# Altium schematic sheet PSDT ADC v1.0.0.SchDoc("PSDT ADC v1.0.0")
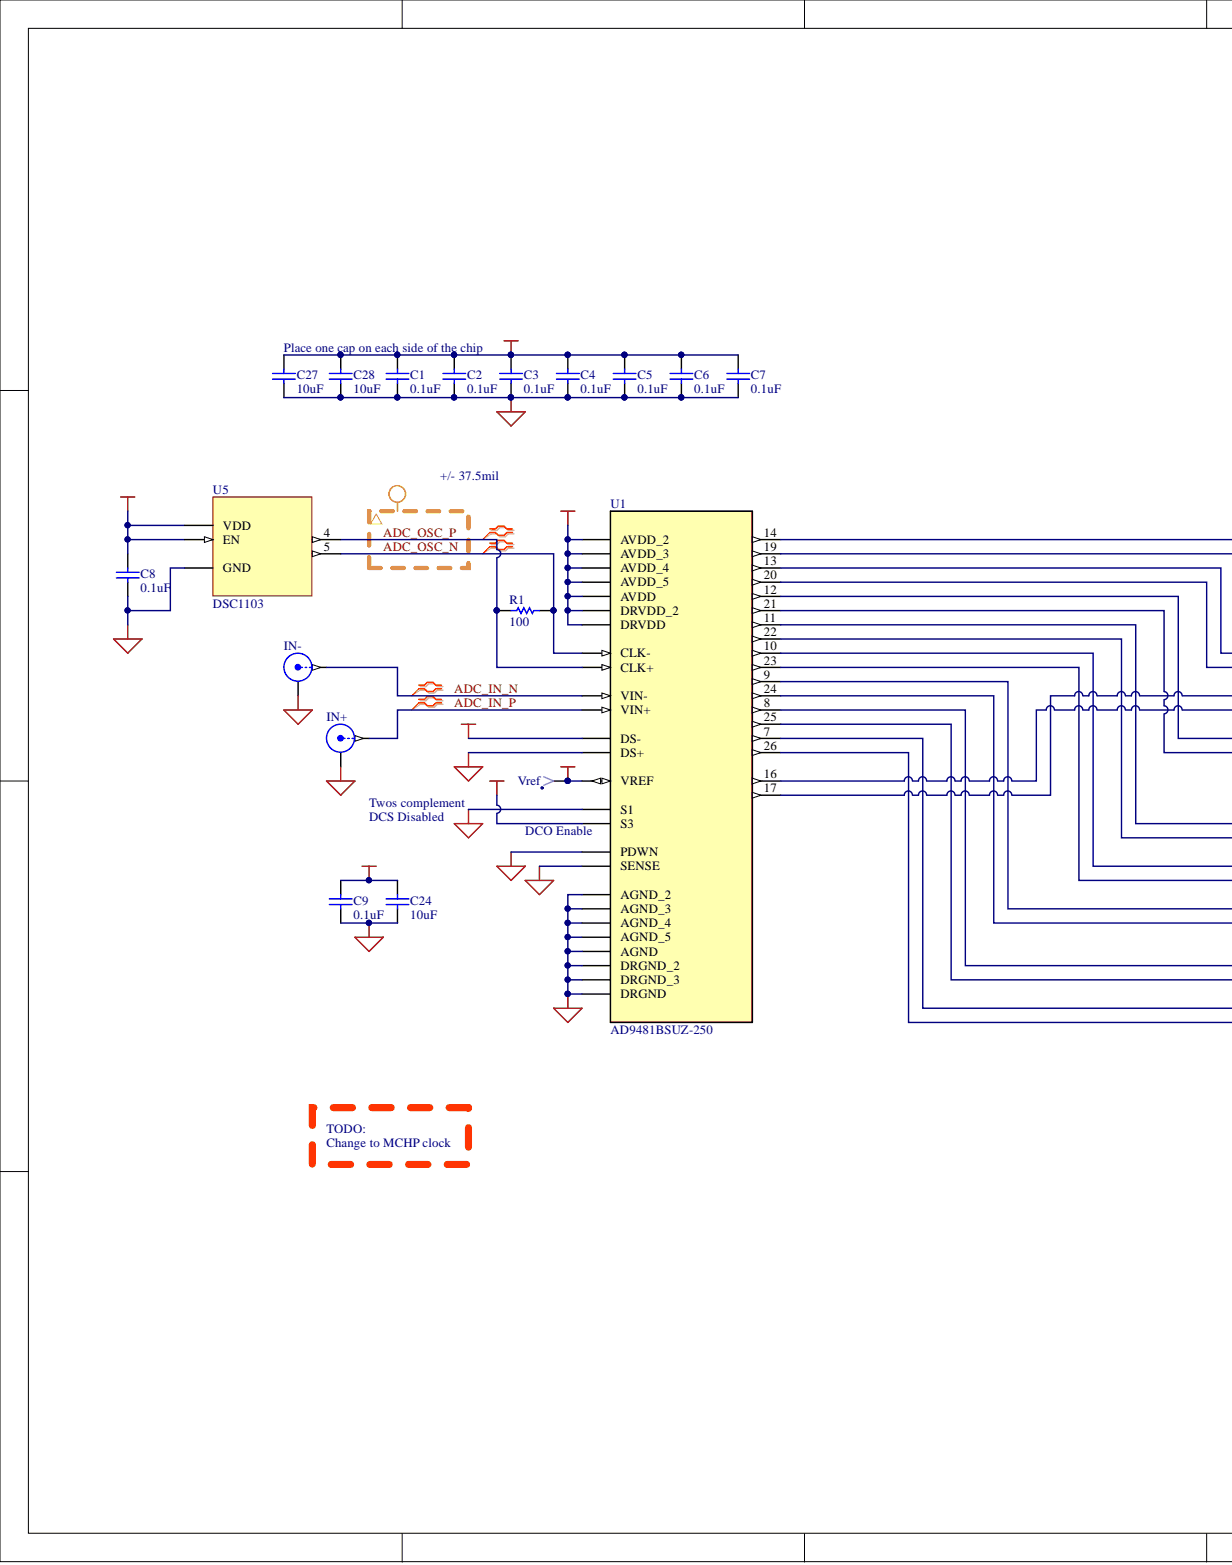
[diagram p0: PSDT ADC v1.0.0.SchDoc, left]
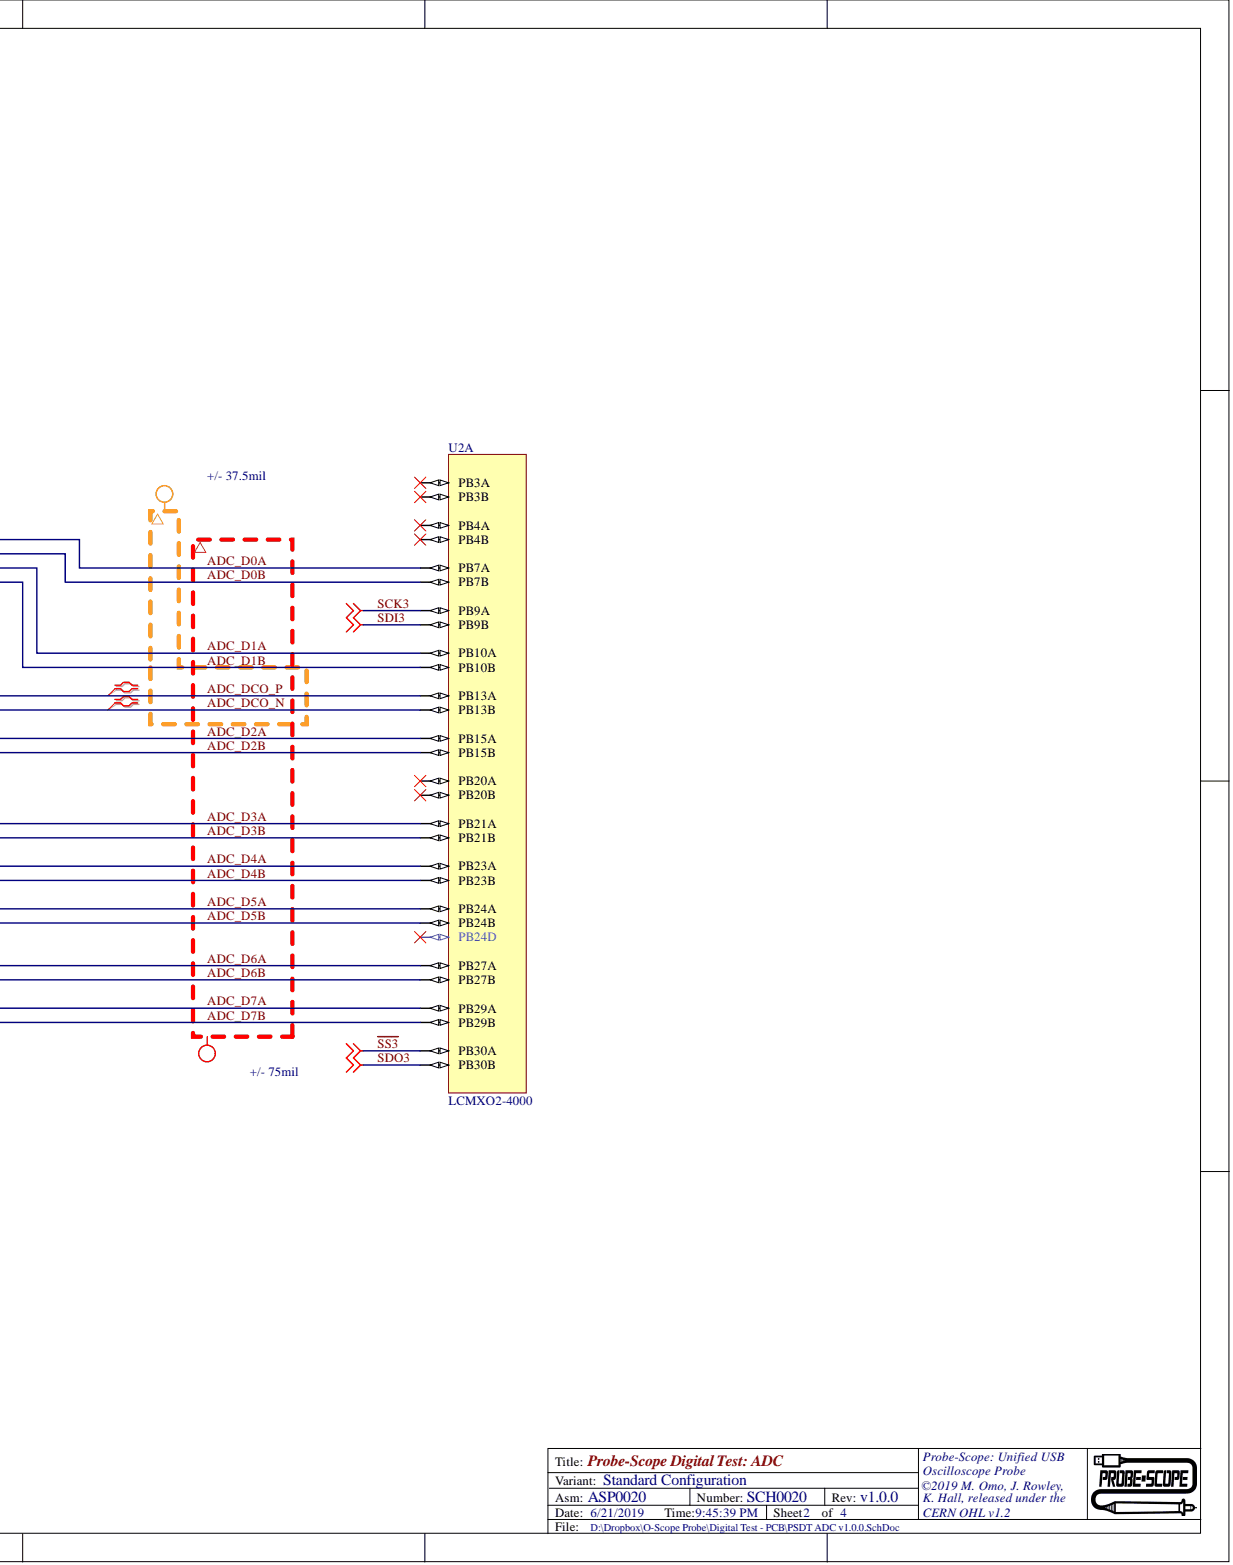
[diagram p1: PSDT ADC v1.0.0.SchDoc, right]

## Parts
C1  value 0.1uF  [0402(1005)]  (hidden comment: 6.3V)
C2  value 0.1uF  [0402(1005)]  (hidden comment: 6.3V)
C3  value 0.1uF  [0402(1005)]  (hidden comment: 6.3V)
C4  value 0.1uF  [0402(1005)]  (hidden comment: 6.3V)
C5  value 0.1uF  [0402(1005)]  (hidden comment: 6.3V)
C6  value 0.1uF  [0402(1005)]  (hidden comment: 6.3V)
C7  value 0.1uF  [0402(1005)]  (hidden comment: 6.3V)
C8  value 0.1uF  [0402(1005)]  (hidden comment: 6.3V)
C9  value 0.1uF  [0402(1005)]  (hidden comment: 6.3V)
C24  value 10uF  [0603(1608)]  (hidden comment: 25V)
C27  value 10uF  [0603(1608)]  (hidden comment: 25V)
C28  value 10uF  [0603(1608)]  (hidden comment: 25V)
IN+  SMA  [TE SMA Stright]  (value not drawn)
IN-  SMA  [TE SMA Stright]  (value not drawn)
R1  value 100  [0402(1005)]  (hidden comment: Res1)
U1  AD9481BSUZ-250  [QFP80P1200X1200X120-44N]
U2  LCMXO2-4000  [UL_TQFP144]  (part A)
U5  DSC1103  [MCHP_H5A]
Vref  TP  [TESTPOINT024]  (value not drawn)

## Nets
+3.3V: C1.2 C2.2 C3.2 C4.2 C5.2 C6.2 C7.2 C8.2 C27.2 C28.2 U1.3(AVDD_3) U1.5(DRVDD_2) U1.18(DRVDD) U1.30(AVDD_2) U1.31(AVDD_4) U1.36(AVDD_5) U1.41(AVDD) U1.42(S3) U1.43(DS-) U5.1(EN) U5.6(VDD)
GND: C1.1 C2.1 C3.1 C4.1 C5.1 C6.1 C7.1 C8.1 C9.1 C24.1 C27.1 C28.1 IN+.2 IN-.2 U1.4(AGND_2) U1.6(DRGND_2) U1.15(DRGND_3) U1.27(DRGND) U1.28(S1) U1.29(PDWN) U1.32(AGND_3) U1.33(SENSE) U1.35(AGND_4) U1.37(AGND_5) U1.40(AGND) U1.44(DS+) U5.3(GND)
Vref: C9.2 C24.2 U1.34(VREF) Vref.1
ADC_D0A: U1.14 U2.42(PB7A)
ADC_D0B: U1.19 U2.43(PB7B)
ADC_D1A: U1.13 U2.47(PB10A)
ADC_D1B: U1.20 U2.48(PB10B)
ADC_D2A: U1.12 U2.52(PB15A)
ADC_D2B: U1.21 U2.54(PB15B)
ADC_D3A: U1.11 U2.57(PB21A)
ADC_D3B: U1.22 U2.58(PB21B)
ADC_D4A: U1.10 U2.59(PB23A)
ADC_D4B: U1.23 U2.60(PB23B)
ADC_D5A: U1.9 U2.61(PB24A)
ADC_D5B: U1.24 U2.62(PB24B)
ADC_D6A: U1.8 U2.65(PB27A)
ADC_D6B: U1.25 U2.67(PB27B)
ADC_D7A: U1.7 U2.68(PB29A)
ADC_D7B: U1.26 U2.69(PB29B)
ADC_DCO_N: U1.16 U2.50(PB13B)
ADC_DCO_P: U1.17 U2.49(PB13A)
ADC_IN_N: IN-.1 U1.38(VIN-)
ADC_IN_P: IN+.1 U1.39(VIN+)
ADC_OSC_N: R1.2 U1.2(CLK-) U5.5
ADC_OSC_P: R1.1 U1.1(CLK+) U5.4
~{SS3}: U2.70(PB30A)
SCK3: U2.44(PB9A)
SDI3: U2.45(PB9B)
SDO3: U2.71(PB30B)
N00025:
N00026:
N00027:

Not connected: U2 38(PB3A) 39(PB3B) 40(PB4A) 41(PB4B) 55(PB20A) 56(PB20B) 63(PB24D)

## Library data of the parts
C1-C9 = 1875: Voltage: 6.3; VENDOR_PN: 1276-1511-1-ND; VENDOR: Digikey; Type: Ceramic; Tolerance: 10; P&V Description: Capacitor, Ceramic, 0.1uF, 6.3V, 10% Tol, 125C, X7R, 0402, SMD; Other: X7R; MENG_PN: ???1875; Max Temperature: 125; MANF_PN: CL05B104KQ5NNNC; MANF: Samsung Electro-Mechanics; Database Table Name: Capacitors; Class: Capacitor; Approved: False; Verified: False; SMD: True; Simulation: CAP; Signal Integrity: Cap; Parent Key: 1875; Package: 0402; Key: 1875; Date Modified: 11/6/2018 11:14:02 AM; Component Kind: Standard; Modified By: jrowley; Library Name: MENG Database Library VPN.DbLib
C24 C27 C28 = 1306: Voltage: 25; VENDOR_PN: 1276-1869-1-ND; VENDOR: Digikey; Type: Ceramic; Tolerance: 20; P&V Description: Capacitor, Ceramic, 10uF, 25V, 20% Tol, 85C, X5R, 0603, SMD; Other: X5R; MENG_PN: ???1306; Max Temperature: 85; MANF_PN: CL10A106MA8NRNC; MANF: Samsung Electro-Mechanics; Database Table Name: Capacitors; Class: Capacitor; Approved: True; Verified: True; SMD: True; Simulation: CAP; Signal Integrity: Cap; Parent Key: 1306; Package: 0603; Key: 1306; Date Modified: 3/3/2015 11:08:29 AM; Component Kind: Standard; Modified By: sotterbacher; Library Name: MENG Database Library VPN.DbLib
IN+ IN- = 968: VENDOR_PN: A97594-ND; VENDOR: Digikey; Sex: Female; Positions: 1; P&V Description: Connector, SMA, 1 Positions, Female, Vertical.  50 Ohm.  3 GHz.; Other: Vertical.  50 Ohm.  3 GHz.; MENG_PN: ???968; MANF_PN: 5-1814832-1; MANF: TE Connectivity; Database Table Name: Connectors; Class: Connector; Approved: True; Verified: True; Type: SMA; SMD: False; Signal Integrity: Connector; Parent Key: 968; Key: 968; Date Modified: 5/28/2013 3:41:34 PM; Component Kind: Standard; Modified By: rlaity; Library Name: MENG Database Library VPN.DbLib
R1 = 1823: VENDOR_PN: 311-100LRCT-ND; VENDOR: Digikey; Tolerance: 1; Power: 0.0625; P&V Description: Resistor, Thick Film, 100 Ohms, 0.0625W, 1% Tol, 100V, 0402, SMD; MENG_PN: ???1823; MANF_PN: RC0402FR-07100RL; MANF: Yageo; Database Table Name: Resistive Elements; Class: Resistor; Approved: False; Voltage: 100; Verified: False; Type: Thick Film; SMD: True; Simulation: RESISTOR; Signal Integrity: Res; Parent Key: 1823; Package: 0402; Key: 1823; Expr1026: 1823; Date Modified: 8/29/2018 2:46:23 AM; Component Kind: Standard; Modified By: jrowley; Library Name: MENG Database Library VPN.DbLib
U1 = AD9481BSUZ-250: Single ADC Pipelined 250Msps 8-bit Parallel/LVDS 44-Pin TQFP Tray; VENDOR_PN: AD9481BSUZ-250-ND; VENDOR: DigiKey; MANF: Analog Devices Inc.; MANF_PN: AD9481BSUZ-250; Library Name: PS.SchLib; Component Kind: Standard
U2 = MachXO2-4000-TG144: VENDOR_PN: 220-1460-ND; VENDOR: DigiKey; MANF_PN: LCMXO2-4000HC-4TG144C; MANF: Lattice Semiconductor Corporation; Library Name: PS.SchLib; Component Kind: Standard
U5 = DSC1103: VENDOR_PN: 579-1103CI32500000; VENDOR: Mouser; MANF_PN: DSC1103CI3-250.0000; MANF: Microchip Technology; Library Name: PS v1.0.SchLib; Component Kind: Standard
Vref = 49: VENDOR_PN: 1998-3K-ND; VENDOR: Digikey; Sex: Male; Positions: 1; P&V Description: Connector, Test Point, 1 Positions, Male, Small, 0.27" Straight Pin, 0.02" dia; Other: Small, 0.27" Straight Pin, 0.02" dia; MENG_PN: CON00002; MANF_PN: 1998-3; MANF: Keystone Electronics; Database Table Name: Connectors; Class: Connector; Approved: True; Verified: True; Type: Test Point; SMD: False; Signal Integrity: Connector; Parent Key: 49; Key: 49; Date Modified: 9/30/2014 10:31:54 AM; Component Kind: Standard; Modified By: sotterbacher; Library Name: MENG Database Library VPN.DbLib
